# Altium schematic sheet lv_io.SchDoc(lv_io)
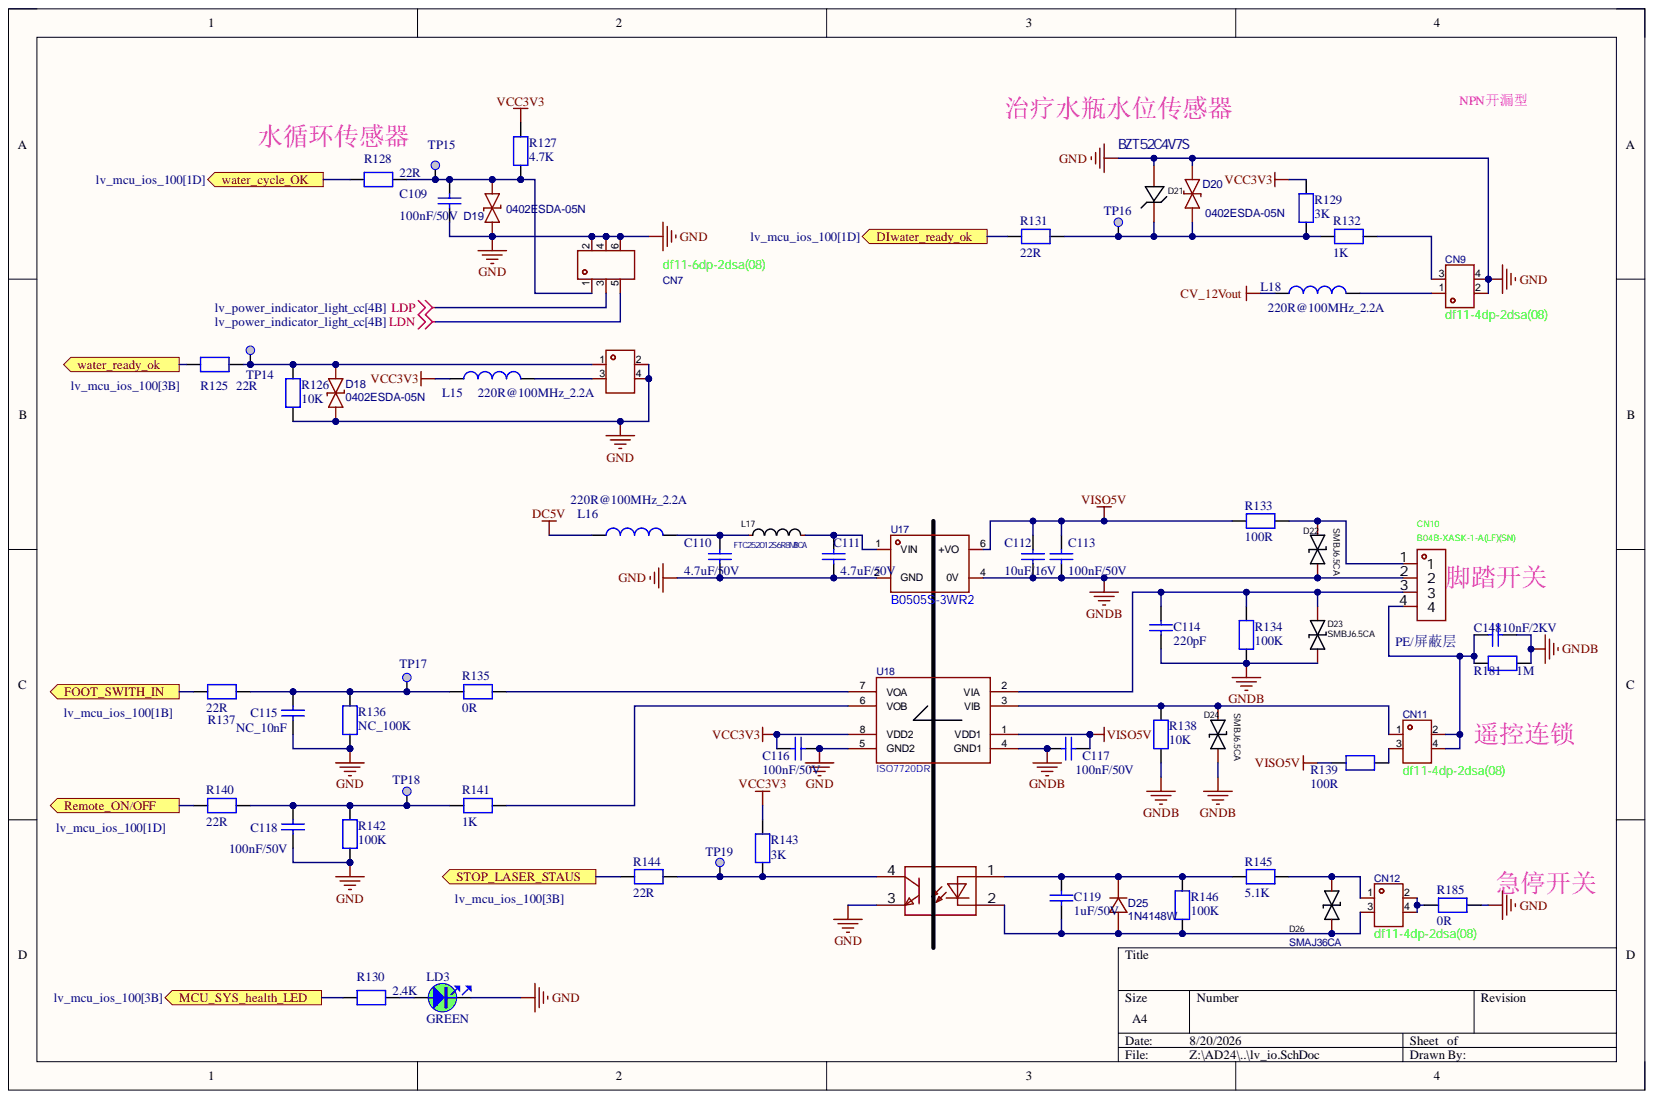
[diagram p0: lv_io.SchDoc]

## Parts
C109  100nF/50V  [C0603]
C110  4.7uF/50V  [C1206]
C111  4.7uF/50V  [C1206]
C112  10uF/16V  [C0805]
C113  100nF/50V  [C0603]
C114  220pF  [C0603]
C115  NC_10nF  [C0603]
C116  100nF/50V  [C0603]
C117  100nF/50V  [C0603]
C118  100nF/50V  [C0603]
C119  1uF/50V  [C0603]
C148  10nF/2KV  [c1812]
CN6  df11-4dp-2dsa(08)  [CONN-TH_DF11-4DP-2DSA-08]
CN7  df11-6dp-2dsa(08)  [CONN-TH_DF11-6DP-2DSA-01]
CN9  df11-4dp-2dsa(08)  [CONN-TH_DF11-4DP-2DSA-08]
CN10  B04B-XASK-1-A(LF)(SN)  [CONN-TH_B04B-XASK-1-A-LF-SN]
CN11  df11-4dp-2dsa(08)  [CONN-TH_DF11-4DP-2DSA-08]
CN12  df11-4dp-2dsa(08)  [CONN-TH_DF11-4DP-2DSA-08]
D18  0402ESDA-05N  [D0402-BI]
D19  0402ESDA-05N  [D0402-BI]
D20  0402ESDA-05N  [D0402-BI]
D21  BZT52C4V7S  [SOD-323_L1.7-W1.3-LS2.5-FD]
D22  SMBJ6.5CA  [SMB_L4.6-W3.6-LS5.3-BI]
D23  SMBJ6.5CA  [SMB_L4.6-W3.6-LS5.3-BI]
D24  SMBJ6.5CA  [SMB_L4.6-W3.6-LS5.3-BI]
D25  1N4148W  [SOD-123_L2.8-W1.8-LS3.7-RD]
D26  SMAJ36CA  [SMA_L4.4-W2.6-LS5.0-BI]
L15  220R@100MHz_2.2A  [L0603]
L16  220R@100MHz_2.2A  [L0603]
L17  FTC252012S6R8MBCA  [IND-SMD_L2.5-W2.0_WPN252012H]
L18  220R@100MHz_2.2A  [L0603]
LD3  value GREEN  [LED_0603_GREEN]  (hidden comment: LED_green)
R125  22R  [R0402]
R126  10K  [R0603]
R127  4.7K  [R0402]
R128  22R  [R0402]
R129  3K  [R0603]
R130  2.4K  [R0402]
R131  22R  [R0402]
R132  1K  [R0603]
R133  100R  [R0603]
R134  100K  [R0603]
R135  0R  [R0402]
R136  NC_100K  [R0402]
R137  22R  [R0402]
R138  10K  [R0603]
R139  100R  [R0603]
R140  22R  [R0402]
R141  1K  [R0402]
R142  100K  [R0402]
R143  3K  [R0402]
R144  22R  [R0402]
R145  5.1K  [R0603]
R146  100K  [R0603]
R181  1M  [1206]
R185  0R  [1206]
TP14  Test Point  [TP_SMD_1MM]  (value not drawn)  (no BOM)
TP15  Test Point  [TP_SMD_1MM]  (value not drawn)  (no BOM)
TP16  Test Point  [TP_SMD_1MM]  (value not drawn)  (no BOM)
TP17  Test Point  [TP_SMD_1MM]  (value not drawn)  (no BOM)
TP18  Test Point  [TP_SMD_1MM]  (value not drawn)  (no BOM)
TP19  Test Point  [TP_SMD_1MM]  (value not drawn)  (no BOM)
U17  B0505S-3WR2  [PWRM-TH_BXXXXS-3WR2]
U18  ISO7720DR  [SOIC-8_L5.0-W4.0-P1.27-LS6.0-BL - duplicate1]
U19  EL817S1(C)(TU)-F  [OPTO-SMD-4_L4.6-W6.5-P2.54-LS10.3-TL]  (value not drawn)

## Ports
DIwater_ready_ok, FOOT_SWITH_IN, MCU_SYS_health_LED, Remote_ON/OFF, STOP_LASER_STAUS, water_cycle_OK, water_ready_ok

## Nets
CV_12Vout: L18.2
DC5V: L16.2
GND: C109.1 C110.1 C111.1 C115.2 C116.1 C118.2 CN6.2 CN6.4 CN7.2 CN7.4 CN7.6 CN9.2 CN9.4 D18.1 D19.1 D20.1 D21.2 LD3.2 R126.1 R136.1 R142.1 R185.1 U17.2(GND) U18.5(GND2) U19.3
GNDB: C112.2 C113.1 C114.2 C117.2 C148.2 CN10.2 D22.1 D23.1 D24.1 R134.1 R138.1 R181.2 U17.4(0V) U18.4(GND1)
LDN: CN7.5
LDP: CN7.3
VCC3V3: C116.2 L15.2 R127.1 R129.1 R143.2 U18.8(VDD2)
VISO5V: C112.1 C113.2 C117.1 R133.2 R139.1 U17.6(+VO) U18.1(VDD1)
DIwater_ready_ok: R131.1 ; port DIwater_ready_ok
FOOT_SWITH_IN: R137.2 ; port FOOT_SWITH_IN
MCU_SYS_health_LED: R130.1 ; port MCU_SYS_health_LED
Remote_ON/OFF: R140.1 ; port Remote_ON/OFF
STOP_LASER_STAUS: R144.1 ; port STOP_LASER_STAUS
water_cycle_OK: R128.1 ; port water_cycle_OK
water_ready_ok: R125.1 ; port water_ready_ok
NetC109_2: C109.2 CN7.1 D19.2 R127.2 R128.2 TP15.1
NetC110_2: C110.2 L16.1 L17.1
NetC111_2: C111.2 L17.2 U17.1(VIN)
NetC114_1: C114.1 CN10.3 D23.2 R134.2 U18.2(VIA)
NetC115_1: C115.1 R135.1 R136.2 R137.1 TP17.1
NetC118_1: C118.1 R140.2 R141.2 R142.2 TP18.1
NetC119_1: C119.1 CN12.3 D25.2 D26.2 R146.2 U19.2
NetC119_2: C119.2 D25.1 R145.2 R146.1 U19.1
NetC148_1: C148.1 CN10.4 CN11.2 CN11.4 R181.1
NetCN6_1: CN6.1 D18.2 R125.2 R126.2 TP14.1
NetCN6_3: CN6.3 L15.1
NetCN9_1: CN9.1 L18.1
NetCN9_3: CN9.3 R132.2
NetCN10_1: CN10.1 D22.2 R133.1
NetCN11_1: CN11.1 D24.2 R138.2 U18.3(VIB)
NetCN11_3: CN11.3 R139.2
NetCN12_1: CN12.1 D26.1 R145.1
NetCN12_2: CN12.2 CN12.4 R185.2
NetD20_2: D20.2 D21.1 R129.2 R131.2 R132.1 TP16.1
NetLD3_1: LD3.1 R130.2
NetR135_2: R135.2 U18.7(VOA)
NetR141_1: R141.1 U18.6(VOB)
NetR143_1: R143.1 R144.2 TP19.1 U19.4

## Library data of the parts
C109 C113 C116 C117 = Capacitor_1: 50V, X7R, 10%; Manufacturer: muRata; Manufacturer_Part_Number: GRM188R71H104KA93D; Component Kind: Standard; ComponentLink1Description: Yageo_Capacitor_X5R-series.pdf; ComponentLink1URL: http://tunmcdsvn.tun.st.com/Component_Datasheet_Library/Trunk/Capacitors/Yageo_Multilayer_capacitor_X5R_series_100pF_to_100uF; Library Name: HiPin_Library_AD.IntLib
C110 C111 = Capacitor_2: 50V, X7R, 10%; Internal_Part_Number: MCD-00014; Manufacturer: muRata; Manufacturer_Part_Number: GRM31CR71H475KA12L; Value: 4.7uF; Component Kind: Standard; ComponentLink1Description: Datasheet; ComponentLink1URL: http://tunmcdsvn.tun.st.com/Component_Datasheet_Library/Trunk/Capacitors/Yageo_Multilayer_capacitor_X5R_series_100pF_to_100uF; Library Name: HiPin_Library_AD.IntLib
C112 = Capacitor_1: 50V, X5R, 20%; Manufacturer: muRata; Manufacturer_Part_Number: GRM188R61E106MA73D; Component Kind: Standard; ComponentLink1Description: Yageo_Capacitor_X5R-series.pdf; ComponentLink1URL: http://tunmcdsvn.tun.st.com/Component_Datasheet_Library/Trunk/Capacitors/Yageo_Multilayer_capacitor_X5R_series_100pF_to_100uF; Library Name: HiPin_Library_AD.IntLib
C114 = Capacitor_1: 50V, X7R, 10%; Manufacturer: muRata; Manufacturer_Part_Number: GCM1885C1H221JA16D; Component Kind: Standard; ComponentLink1Description: Yageo_Capacitor_X5R-series.pdf; ComponentLink1URL: http://tunmcdsvn.tun.st.com/Component_Datasheet_Library/Trunk/Capacitors/Yageo_Multilayer_capacitor_X5R_series_100pF_to_100uF; Library Name: HiPin_Library_AD.IntLib
C115 C118 = Capacitor_1: 50V, X5R, 10%; Component Kind: Standard; ComponentLink1Description: Yageo_Capacitor_X5R-series.pdf; ComponentLink1URL: http://tunmcdsvn.tun.st.com/Component_Datasheet_Library/Trunk/Capacitors/Yageo_Multilayer_capacitor_X5R_series_100pF_to_100uF; Library Name: HiPin_Library_AD.IntLib
C119 = Capacitor_1: 50V, X5R, 10%; Manufacturer: YAGEO; Manufacturer_Part_Number: CC0603KRX7R9BB105; Component Kind: Standard; Library Name: HiPin_Library_AD.IntLib; Package: C0603
C148 = Capacitor_3: 2KV, X7R, 10%; Manufacturer: FH; Manufacturer_Part_Number: 1812B103K202NT; Component Kind: Standard; Library Name: HiPin_Library_AD.IntLib
CN6 CN9 CN11 CN12 = df11-4dp-2dsa: 插针结构:2x2P;间距:2mm;安装方式:直插;参考系列:-;总PIN数:4P;排数:2;每排PIN数:2;行距:2mm;额定电流:-;工作温度范围:-;; Manufacturer: HRS(广濑); AddInBom: yes; ModelId: 0e73d8cf2edd4592bd87ebe7b4725fde; ModelTransform: 236.22,196.85,0,0,0,0,0,0,-118.11; Number of PINs Per Row: 2; Pins Structure: 2x2P; Pitch: 2mm; Component Kind: Standard; ConvertToPcb: yes; Current Rating (Max): -; Datasheet: https://atta.szlcsc.com/upload/public/pdf/source/20200402/C506665_F433E61D9F10FCB2CA56C5EB49D0F0CF.pdf; FootprintName: CONN-TH_DF11-4DP-2DSA-08; JLCPCB Part Class: Extended Part; LCSC Part Name: 2x2P 间距:2mm 直插; Library Name: HiPin_Library_AD.IntLib; ManufacturerPart: DF11-4DP-2DSA(08); ModelName: CONN-TH_DF11-4DP-2DSA-08; Mounting Style: 直插; Number of Pins: 4P; Number of Rows: 2; Operating Temperature Range: -; Reference Series: -; Row Spacing: 2mm; Supplier: LCSC; Supplier Footprint: 插件,P=2mm; SupplierPart: C506665; Symbol: DF11-4DP-2DSA(08)
CN7 = df11-6dp-2dsa: 插针结构:2x3P;间距:2mm;安装方式:直插;参考系列:-;总PIN数:6P;排数:2;每排PIN数:3;行距:2mm;额定电流:-;工作温度范围:-;; Manufacturer: HRS(广濑); AddInBom: yes; ModelId: f6522031bd444346802bb24726d5ca22; ModelTransform: 314.96,196.85,0,0,0,0,0,0,-118.11; Number of PINs Per Row: 3; Pins Structure: 2x3P; Pitch: 2mm; Component Kind: Standard; ConvertToPcb: yes; Current Rating (Max): -; Datasheet: https://atta.szlcsc.com/upload/public/pdf/source/20200402/C506668_15971A609117B16797466F71D3345786.pdf; FootprintName: CONN-TH_DF11-6DP-2DSA-01; JLCPCB Part Class: Extended Part; LCSC Part Name: 2x3P 间距:2mm 直插; Library Name: HiPin_Library_AD.IntLib; ManufacturerPart: DF11-6DP-2DSA(08); ModelName: CONN-TH_DF11-6DP-2DSA-01; Mounting Style: 直插; Number of Pins: 6P; Number of Rows: 2; Operating Temperature Range: -; Reference Series: -; Row Spacing: 2mm; Supplier: LCSC; Supplier Footprint: 插件,P=2mm; SupplierPart: C506668; Symbol: DF11-6DP-2DSA(08)
CN10 = B04B-XASK-1-A(LF)(SN): 插座XA2.5mm,3A,H8.8mm; Manufacturer: JST; Add into BOM: yes; Flame Retardant Rating: UL94V-0; Number of PINs Per Row: 4; Pins Structure: 1x4P; Pitch: 2.5mm; Plastic Material: 玻纤增强PA66; Rated Voltage (Max): 250V; Reference Series: XA; Supplementary Features: 带柱；打K脚; X-Length of Bottom Edge on Board (Spacing Line): 12.5mm; Y-Width of Bottom Edge on Board: 6.4mm; Z-Height of the Board: 8.8mm; Colour: 白色; Component Kind: Standard; Contact Material: 铜合金; Contact Plating: 锡; Convert to PCB: yes; Current Rating (Max): 3A; Datasheet: https://atta.szlcsc.com/upload/public/pdf/source/20200416/C514162_2DCD7D1CD2019D14145B8FEA1B6236C2.pdf; Device: B04B-XASK-1-A(LF)(SN); JLCPCB Part Class: Extended Part; LCSC Part Name: 1x4P 间距:2.5mm 直插 系列:XA; Library Name: HiPin_Library_AD.IntLib; Manufacturer Part: B04B-XASK-1-A(LF)(SN); Mounting Style: 直插; Number of Pins: 4P; Number of Rows: 1; Operating Temperature Range: -25℃~+85℃; PCB3D: CONN-TH_B04B-XASK-1-A-LF-SN; Row Spacing: -; Supplier: LCSC; Supplier Footprint: 插件,P=2.5mm; Supplier Part: C491709; Symbol: B04B-XASK-1-A(LF)(SN)
D18-D20 = 0402ESDA-05N: 双向ESD，反向截止电压5V; BOM_Manufacturer: Bourne Semicon (Shenzhen); Contributor: LCSC; BOM_JLCPCB Part Class: Extended Part; BOM_Manufacturer Part: 0402ESDA-05N; BOM_Supplier: LCSC; BOM_Supplier Part: C316049; Component Kind: Standard; Library Name: HiPin_Library_AD.IntLib; spicePre: D; spiceSymbolName: 0402ESDA-05N
D21 = BZT52C4V7S: 4.4V~5V稳压二极管; Component Kind: Standard; Library Name: HiPin_Library_AD.IntLib
D22-D24 = SMBJ18CA_C113991: Vrwm6.5, 最大钳位电压11.2V， 击穿电压7.98V; Manufacturer: MDD; Add into BOM: yes; Breakdown Voltage: 20V; Maximum Clamping Voltage: 29.2V; Peak Pulse Current (Ipp)@10/1000us: 20.5A; Reverse Stand-Off Voltage (Vrwm): 18V; Symbol: SMBJ18CA-C113991; Type: TVS; Component Kind: Standard; Convert to PCB: yes; Datasheet: https://atta.szlcsc.com/upload/public/pdf/source/20230314/C8F66EF07395B88C11214ABD10019202.pdf; Device: SMBJ18CA_C113991; JLCPCB Part Class: Extended Part; LCSC Part Name: SMBJ18CA 双向TVS Vrwm:18V; Library Name: HiPin_Library_AD.IntLib; Manufacturer Part: SMBJ6.5CA; Name: SMBJ6.5CA; PCB3D: SMB_L4.6-W3.6-LS5.3-BI; Supplier: LCSC; Supplier Footprint: SMB(DO-214AA); Supplier Part: C113991
D25 = 1N4148W-C84367: BOM_Manufacturer: MDD; BOM_JLCPCB Part Class: Extended Part; BOM_Manufacturer Part: 1N4148W; BOM_Supplier: LCSC; BOM_Supplier Part: C84367; Component Kind: Standard; Library Name: HiPin_Library_AD.IntLib; spicePre: D; spiceSymbolName: 1N4148W-C84367
D26 = SMAJ6.8CA_C406209: 双向TVS,反向截止电压(Vrwm): 36V; Manufacturer: BORN(�����뵼��); Add into BOM: yes; Reverse Stand-Off Voltage (Vrwm): 6.8V; Type: TVS; Component Kind: Standard; Convert to PCB: yes; Datasheet: https://atta.szlcsc.com/upload/public/pdf/source/20190726/C406209_A574BC8626299E1E05AC1B67D2991239.pdf; JLCPCB Part Class: Extended Part; LCSC Part Name: ˫��TVS 6.8V��ֹ; Library Name: HiPin_Library_AD.IntLib; Manufacturer Part: SMAJ36CA; Supplier: LCSC; Supplier Footprint: SMA(DO-214AC); Supplier Part: C406209
L15 L16 L18 = MCD-00047: SMD Chip ferrite bead, 220R@100MHz +/-25%,2.2A, 50mohm; Manufacturer: Sunlord; Manufacturer_Part_Number: UPZ1608U221-2R2TF; Database Table Name: MCD_PARTS$; Component Kind: Standard; ComponentLink1Description: Datasheet; ComponentLink1URL: http://tunmcdsvn.tun.st.com/Component_Datasheet_Library/Trunk/Inductors/TaiTech_Bead_FCM1608_series; Internal_Part_Number: MCD-00047; Library Name: MCD_PARTS_database.DbLib
L17 = WPN252012H1R0MT: 6.8uH,±20%,1.6A; Component Kind: Standard; Library Name: HiPin_Library_AD.IntLib; PCB3D: IND-SMD_L2.5-W2.0-H1.2-252012
LD3 = LED_green: LED, Green, 0603; Internal_Part_Number: MCD-00050; Manufacturer: EVERLIGHT; Manufacturer_Part_Number: 19-213SYGC/S530-E2/TR8; Part_Type: Display; ECCN_EU: NEC; ECCN_US: EAR99; Provided_By: SUBCO; Component Kind: Standard; ComponentLink1Description: Datasheet; ComponentLink1URL: http://tunmcdsvn.tun.st.com/Component_Datasheet_Library/Trunk/Display/Everlight_SMD-LED-GREEN_19-213SYGC-S530-XX-TR8-v3.doc; Library Name: mb1367.IntLib; Package: LED 0603; Status: Released
R125 R127-R137 R139-R146 R185 = RES: 1%; Value: 10K; Component Kind: Standard; Library Name: HiPin_Library_AD.IntLib
R126 R138 = RES: 1%; Component Kind: Standard; Library Name: HiPin_Library_AD.IntLib; Value: 10K
R181 = RES: 1%; Manufacturer: YAGEO; Manufacturer_Part_Number: RC1206FR-071ML; Component Kind: Standard; Library Name: HiPin_Library_AD.IntLib
TP14-TP19 = MCD-00074: Test Point 1mm; Part_Type: Mechanical; Database Table Name: MCD_PARTS$; ComponentLink1Description: Datasheet; ComponentLink1URL: http://na; ECCN_EU: n/a; ECCN_US: n/a; Internal_Part_Number: MCD-00074; Library Name: MCD_PARTS_database.DbLib; Manufacturer: n/a; Manufacturer_Part_Number: n/a; Provided_By: n/a; Status: Released
U17 = B0505S-3WR2_C7500927: 5V,3W,隔离电源; Component Kind: Standard; Library Name: HiPin_Library_AD.IntLib; Symbol: B0505S-3WR2_C7500927
U18 = ADuM1200ARZ-RL7: 5KV数字隔离器，2通道; Component Kind: Standard; Library Name: HiPin_Library_AD.IntLib
U19 = EL817S1(C)(TU)-F: 光耦; Manufacturer: EVERLIGHT(亿光); Add into BOM: yes; Collector-Emitter Saturation Voltage (Vce(sat)@Ic,IF): 100mV@1mA,20mA; Fall time: 18us@2mA,100Ω; Forward Voltage: 1.2V; Input voltage type: DC; Isolation Voltage(rms): 5kV; Load Voltage: 35V; Number of Outputs: 1; Output Current: 50mA; Output Type: 光电三极管; Reverse Voltage: 6V; Component Kind: Standard; Convert to PCB: yes; Datasheet: https://atta.szlcsc.com/upload/public/pdf/source/20181026/C323798_FBCE14484708FE0807B9A090D624C4F0.pdf; Device: EL817S1(C)(TU)-F; JLCPCB Part Class: Basic Part; LCSC Part Name: DC输入 隔离电压(rms):5000V; Library Name: HiPin_Library_AD.IntLib; Manufacturer Part: EL817S1(C)(TU)-F; Operating Temperature: -55℃~+110℃; Rise time: 18us@2mA,100Ω; Supplier: LCSC; Supplier Footprint: SMD-4P; Supplier Part: C106900; Symbol: EL817S1(C)(TU)-F
